# Altium schematic sheet Manettino.SchDoc(Manettino)
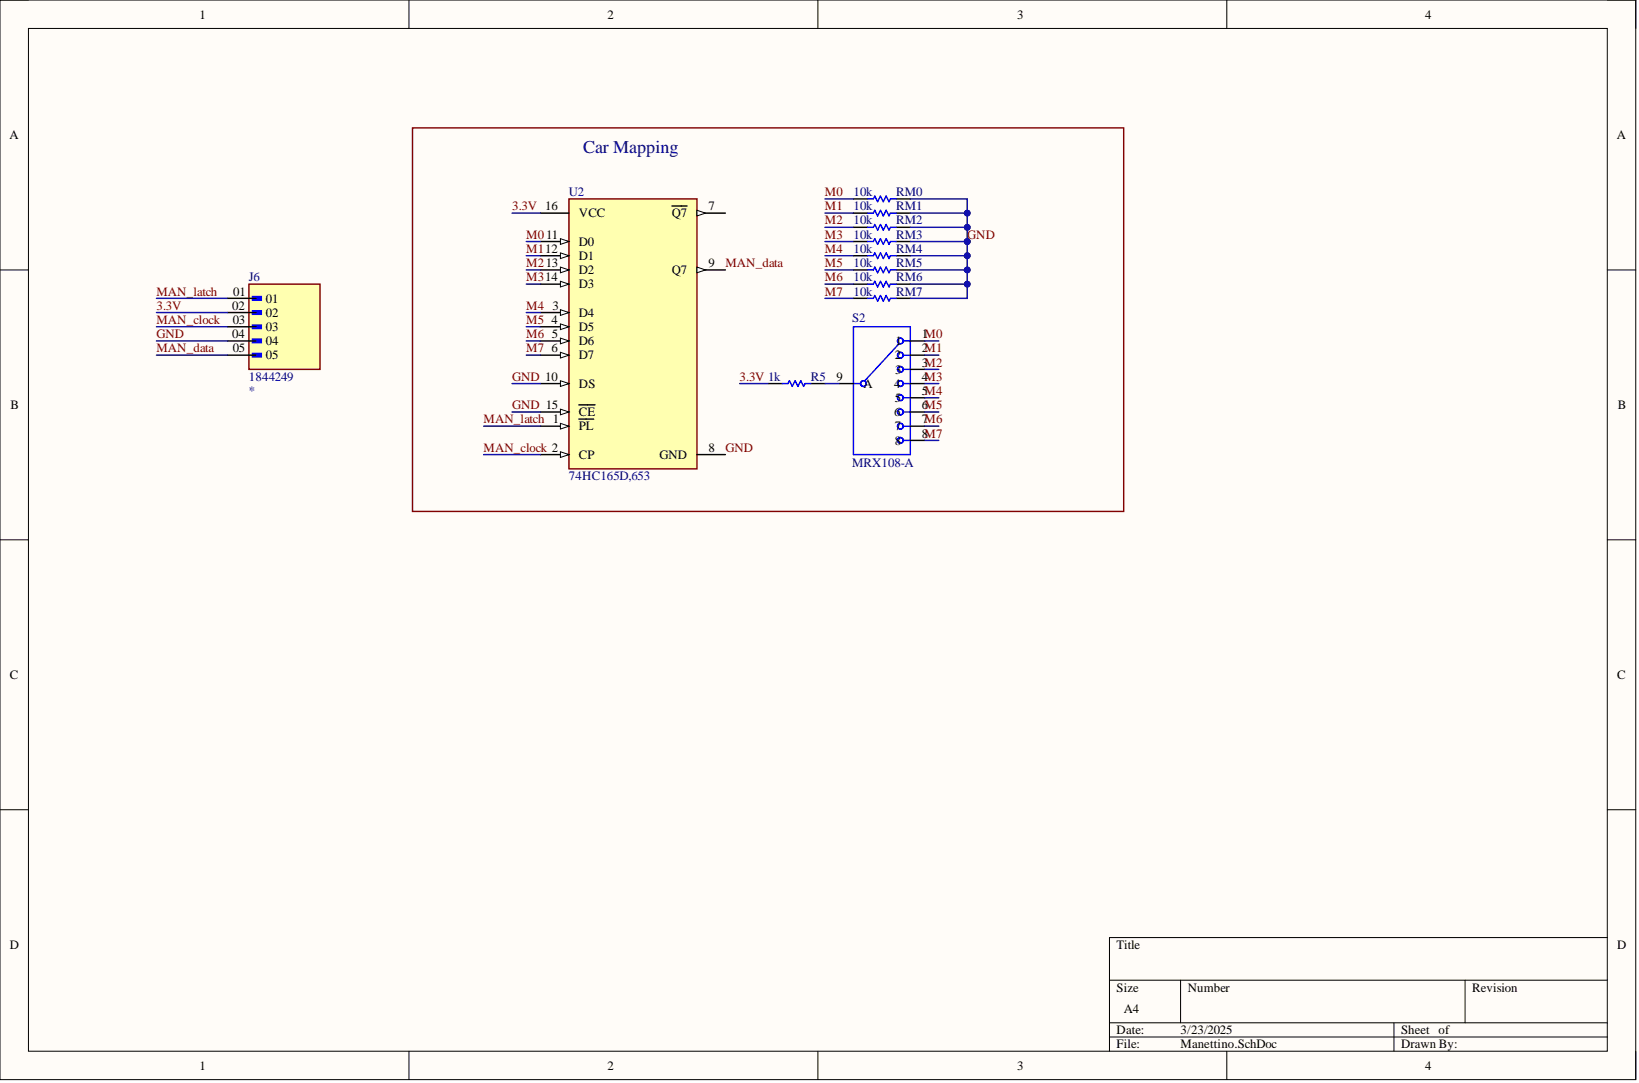
[diagram p0: Manettino.SchDoc]

## Parts
J6  1844249
R5  value 1k  (hidden comment: Resistor 1k +/-1% 0402 100 mW)
RM0  value 10k  (hidden comment: Resistor 1k +/-1% 0402 100 mW)
RM1  value 10k  (hidden comment: Resistor 1k +/-1% 0402 100 mW)
RM2  value 10k  (hidden comment: Resistor 1k +/-1% 0402 100 mW)
RM3  value 10k  (hidden comment: Resistor 1k +/-1% 0402 100 mW)
RM4  value 10k  (hidden comment: Resistor 1k +/-1% 0402 100 mW)
RM5  value 10k  (hidden comment: Resistor 1k +/-1% 0402 100 mW)
RM6  value 10k  (hidden comment: Resistor 1k +/-1% 0402 100 mW)
RM7  value 10k  (hidden comment: Resistor 1k +/-1% 0402 100 mW)
S2  MRX108-A
U2  74HC165D,653

## Nets
3.3V: J6.02 R5.1 U2.16(VCC)
GND: J6.04 RM0.2 RM1.2 RM2.2 RM3.2 RM4.2 RM5.2 RM6.2 RM7.2 U2.8(GND) U2.10(DS) U2.15(CE)
M0: RM0.1 S2.1 U2.11(D0)
M1: RM1.1 S2.2 U2.12(D1)
M2: RM2.1 S2.3 U2.13(D2)
M3: RM3.1 S2.4 U2.14(D3)
M4: RM4.1 S2.5 U2.3(D4)
M5: RM5.1 S2.6 U2.4(D5)
M6: RM6.1 S2.7 U2.5(D6)
M7: RM7.1 S2.8 U2.6(D7)
MAN_clock: J6.03 U2.2(CP)
MAN_data: J6.05 U2.9(Q7)
MAN_latch: J6.01 U2.1(PL)
NetR5_2: R5.2 S2.9(A)

Not connected: U2 7(Q7)

## Library data of the parts
J6 = CMP-090-000000-1: MF: {'manufacturer': u'Phoenix Contact'}; Availability: Unavailable; Component Kind: Standard; Component Type: Standard; Design Item ID: 1844249; MP: 1844249; Package: None; Pin Count: 5; Price: None; Source: 1844249.SchLib; Library Name: Polimarche Racing Team- Polytechnic University of Marche
R5 RM0-RM7 = CMP-009-00009-5: Chip Resistor, 1 KOhm, +/- 1%, 0.1 W, -55 to 155 degC, 0402 (1005 Metric); Power: 100mW; Voltage Rating: 50V; Case/Package: 0402; Component Kind: Standard; Max Operating Temperature: 155°C; Min Operating Temperature: -55°C; Mounting Technology: SM; Pins: 2; RoHS Compliant: True; Tolerance: 1%; Library Name: Polimarche Racing Team- Polytechnic University of Marche
S2 = CMP-077-000000-1: Rotary Switch 2 ~ 8 Position SP8T 2A (AC), 1A (DC) 125VAC Panel Mount, Through Hole; MF: NKK Switches; Availability: Warning; Check_prices: https://www.snapeda.com/parts/MRX108-A/NKK%20Switches/view-part/443678/?ref=eda; Component Kind: Standard; Component Type: Standard; Design Item ID: MRX108-A; MP: MRX108-A; Package: None; Pin Count: 9; Price: None; Purchase-URL: https://pricing.snapeda.com/search/part/MRX108-A/?ref=eda; SnapEDA_Link: https://www.snapeda.com/parts/MRX108-A/NKK%20Switches/view-part/443678/?ref=snap; Source: MRX108-A.SchLib; Library Name: Polimarche Racing Team- Polytechnic University of Marche
U2 = CMP-14351-000001-1: IC SHIFT REGST 8BIT PI-SO 16SOIC; Manufacturer: Nexperia; Frequency: 61MHz; Logic Function Desc: Shift Register; Max Supply Voltage: 6V; Min Supply Voltage: 2V; Nominal Supply Current: 8uA; Number Of Circuits: 1; Number Of Inputs: 8; Propagation Delay: 15ns; Standoff Height: 0.1mm; Ambient Temperature Range High: +125°C; Ambient Temperature Range Low: -40°C; Automotive: No; Category: IC; Component Kind: Standard; ComponentLink2Description: Datasheet; ComponentLink2URL: https://assets.nexperia.com/documents/data-sheet/74HC_HCT165.pdf; Device Class L1: Integrated Circuits (ICs); Device Class L2: Logic ICs; Device Class L3: Shift Registers; Digikey Description: IC SHIFT REGST 8BIT PI-SO 16SOIC; Footprint Url: https://assets.nexperia.com/documents/outline-drawing/SOT109-1.pdf; Height: 1.75mm; Ipc Land Pattern Name: SOP127P600X175-16; Lead Free: Yes; Library Name: Altium Content Vault; Manufacturer Part Number: 74HC165D,653; Number Of Outputs: 1; Octopart: https://octopart.com/74hc165d%2C653-nexperia-78737091; Package: SOT109-1; RoHS: TRUE; Temperature: -40°C to +125°C; Temperature Range High: +125°C; Temperature Range Low: -40°C
